# Altium schematic sheet DVT-Volga.SchDoc(DVT-Volga)
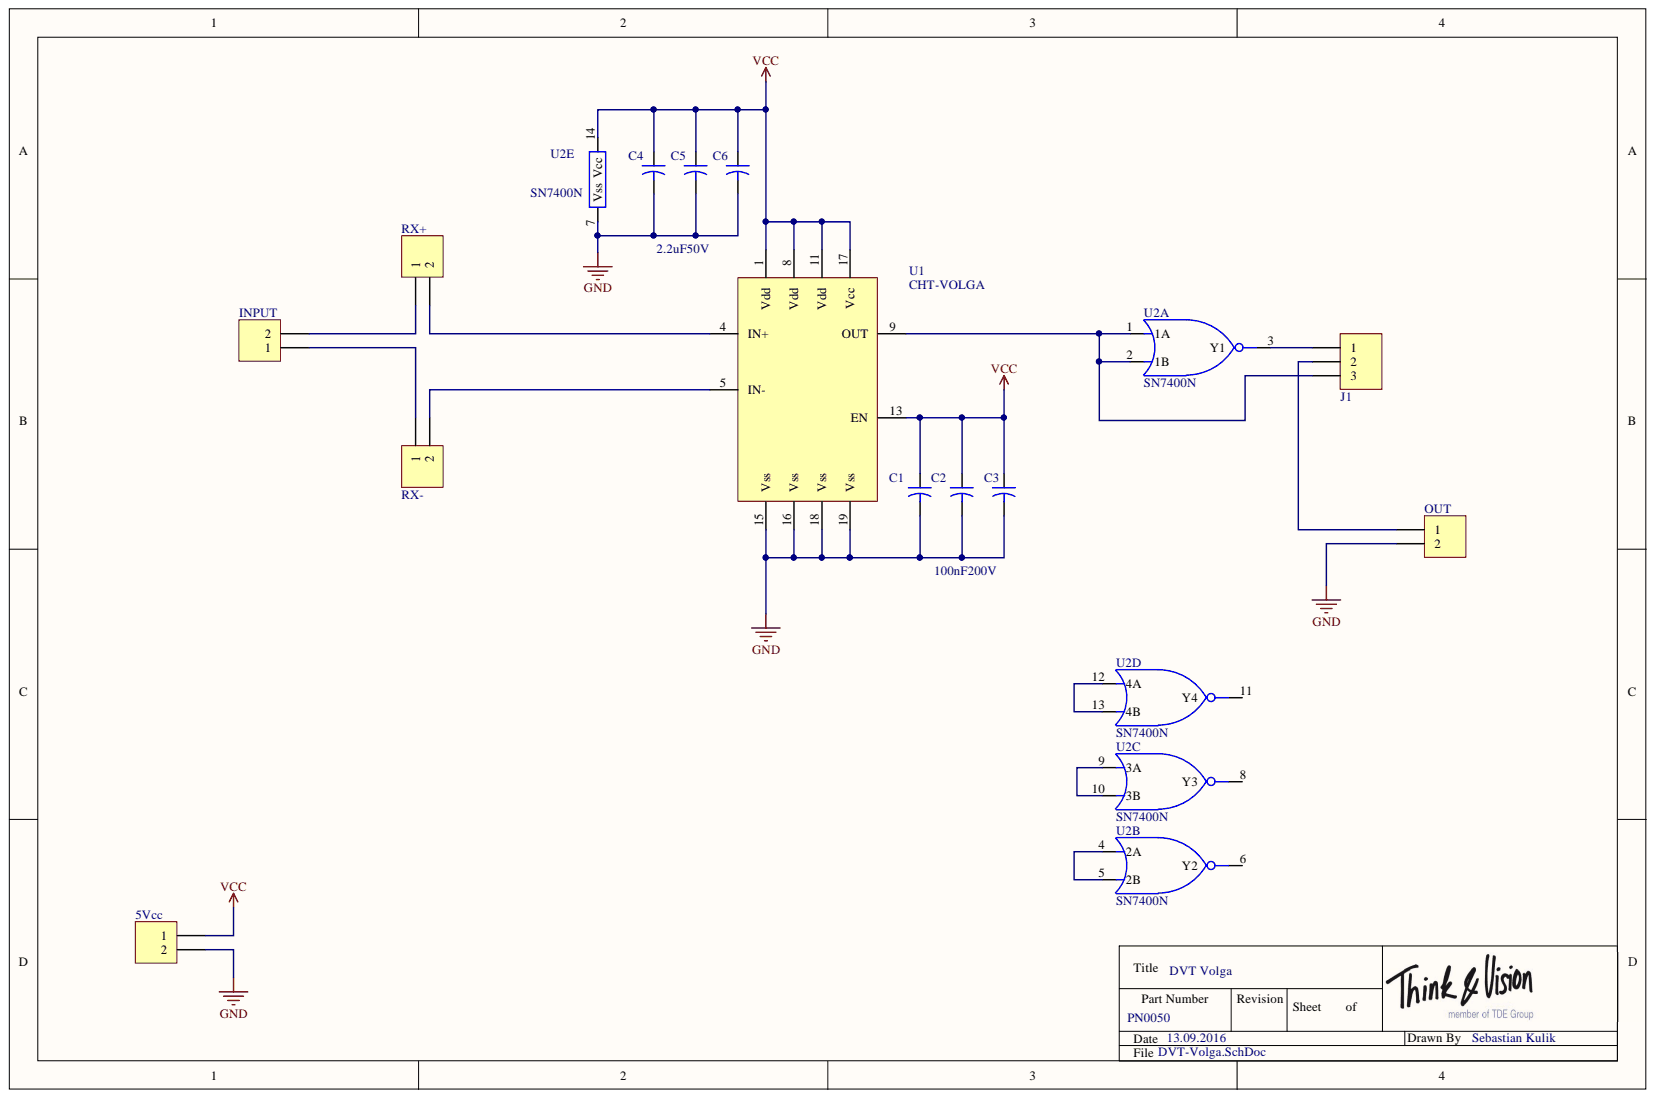
[diagram p0: DVT-Volga.SchDoc]

## Parts
5Vcc  PHOENIX_1715721  [Phoenix-2-5_08]  (value not drawn)
C1  value 100nF200V  [Dummy-10mm]  (hidden comment: Capacitor-Dummy-THT)
C2  value 100nF200V  [Dummy-10mm]  (hidden comment: Capacitor-Dummy-THT)
C3  value 100nF200V  [Dummy-10mm]  (hidden comment: Capacitor-Dummy-THT)
C4  Capacitor-Dummy-THT  [Dummy-10mm]  (value not drawn)
C5  Capacitor-Dummy-THT  [Dummy-10mm]  (value not drawn)
C6  Capacitor-Dummy-THT  [Dummy-10mm]  (value not drawn)
INPUT  PHOENIX_1715721  [Phoenix-2-5_08]  (value not drawn)
J1  Header-3  [HDR1X3]  (value not drawn)
OUT  PHOENIX_1715721  [Phoenix-2-5_08]  (value not drawn)
RX+  PHOENIX_1715721  [HDR1X2]  (value not drawn)
RX-  PHOENIX_1715721  [HDR1X2]  (value not drawn)
U1  CHT-VOLGA  [TDFP16]
U2  SN7400N  [DIP-14]  (parts A,B,C,D,E)

## Nets
GND: 5Vcc.2 C1.2 C2.2 C3.2 C4.2 C5.2 C6.2 OUT.2 U1.15(Vss) U1.16(Vss) U1.18(Vss) U1.19(Vss) U2.7(Vss)
VCC: 5Vcc.1 C1.1 C2.1 C3.1 C4.1 C5.1 C6.1 U1.1(Vdd) U1.8(Vdd) U1.11(Vdd) U1.13(EN) U1.17(Vcc) U2.14(Vcc)
NetINPUT_1: INPUT.1 RX-.1
NetINPUT_2: INPUT.2 RX+.1
NetJ1_1: J1.1 U2.3
NetJ1_2: J1.2 OUT.1
NetJ1_3: J1.3 U1.9(OUT) U2.1(1A) U2.2(1B)
NetRX+_2: RX+.2 U1.4(IN+)
NetRX-_2: RX-.2 U1.5(IN-)
NetU2_4: U2.4(2A) U2.5(2B)
NetU2_9: U2.9(3A) U2.10(3B)
NetU2_12: U2.12(4A) U2.13(4B)

Not connected: U2 6 8 11

## Library data of the parts
5Vcc INPUT OUT = 2Pol_1715721: Phoenix, 2 pol, 5.08mm, 22A, 715721; Deliverer PartNr: 193-0564; Deliverer: RS; TDE-PN: CON0008; Component Kind: Standard; LatestRevisionDate: 02.05.2014; LatestRevisionNote: Re-released for DXP Platform.; Library Name: Connectors.IntLib; Manufacturer: Phoenix; Manufacturer PartNr: 1715721; PackageDescription: Phoenix-2-5_08; Price: 0.976/5; Signal Integrity: Connector; Publisher: Sebastian Kulik
C1-C3 = Capacitor-Dummy-THT: Deliverer PartNr: 2544877; Deliverer: Farnell; Component Kind: Standard; Description: Capacitor-Dummy-THT; Library Name: Capacitors.IntLib; Manufacturer: -; Manufacturer PartNr: -; PackageDescription: -; Part Description: Capacitor-Dummy-THT; Price: 0.51/10; Published: 08.12.2015; Signal Integrity: Cap; Simulation: CAP; TDE-PN: -; Publisher: Sebastian Kulik
C4-C6 = Capacitor-Dummy-THT: Deliverer PartNr: 2529490; Deliverer: Farnell; Value: 2.2uF50V; Component Kind: Standard; Description: Capacitor-Dummy-THT; Library Name: Capacitors.IntLib; Manufacturer: -; Manufacturer PartNr: -; PackageDescription: -; Part Description: Capacitor-Dummy-THT; Price: 1.28/1; Published: 08.12.2015; Signal Integrity: Cap; Simulation: CAP; TDE-PN: -; Publisher: Sebastian Kulik
J1 = Header-3: Header-3-Pin; Component Kind: Standard; Library Name: Connectors.IntLib; Publisher: Sebastian Kulik
RX+ RX- = Header-2: Header, 2-Pin; Component Kind: Standard; LatestRevisionDate: 18.02.2014; LatestRevisionNote: Re-released for DXP Platform.; Library Name: Connectors.IntLib; Signal Integrity: Connector; Publisher: Sebastian Kulik
U1 = CHT-VOLGA: Comparator, Hi-Temp, 25MHz; Deliverer: CISSOID; TDE-PN: IC0080; Component Kind: Standard; Deliverer PartNr: CHT-VOLGA; Library Name: ICs.IntLib; Manufacturer: CISSOID; Manufacturer PartNr: CHT-VOLGA; PackageDescription: DIP16; Price: 204.93/5; Published: 01.08.2016; Publisher: Sebastian Kulik
U2 = SN7400N: Quad NAND, TTL; Deliverer PartNr: 305-490; Manufacturer: TI; Deliverer: RS; TDE-PN: IC0076; Component Kind: Standard; Library Name: ICs.IntLib; Manufacturer PartNr: SN7400N; PackageDescription: SOIC28; Price: 1.45; Published: 13.05.2016; Publisher: Sebastian Kulik
